# Altium schematic sheet Beagle Bone Black C Cape USB.SchDoc
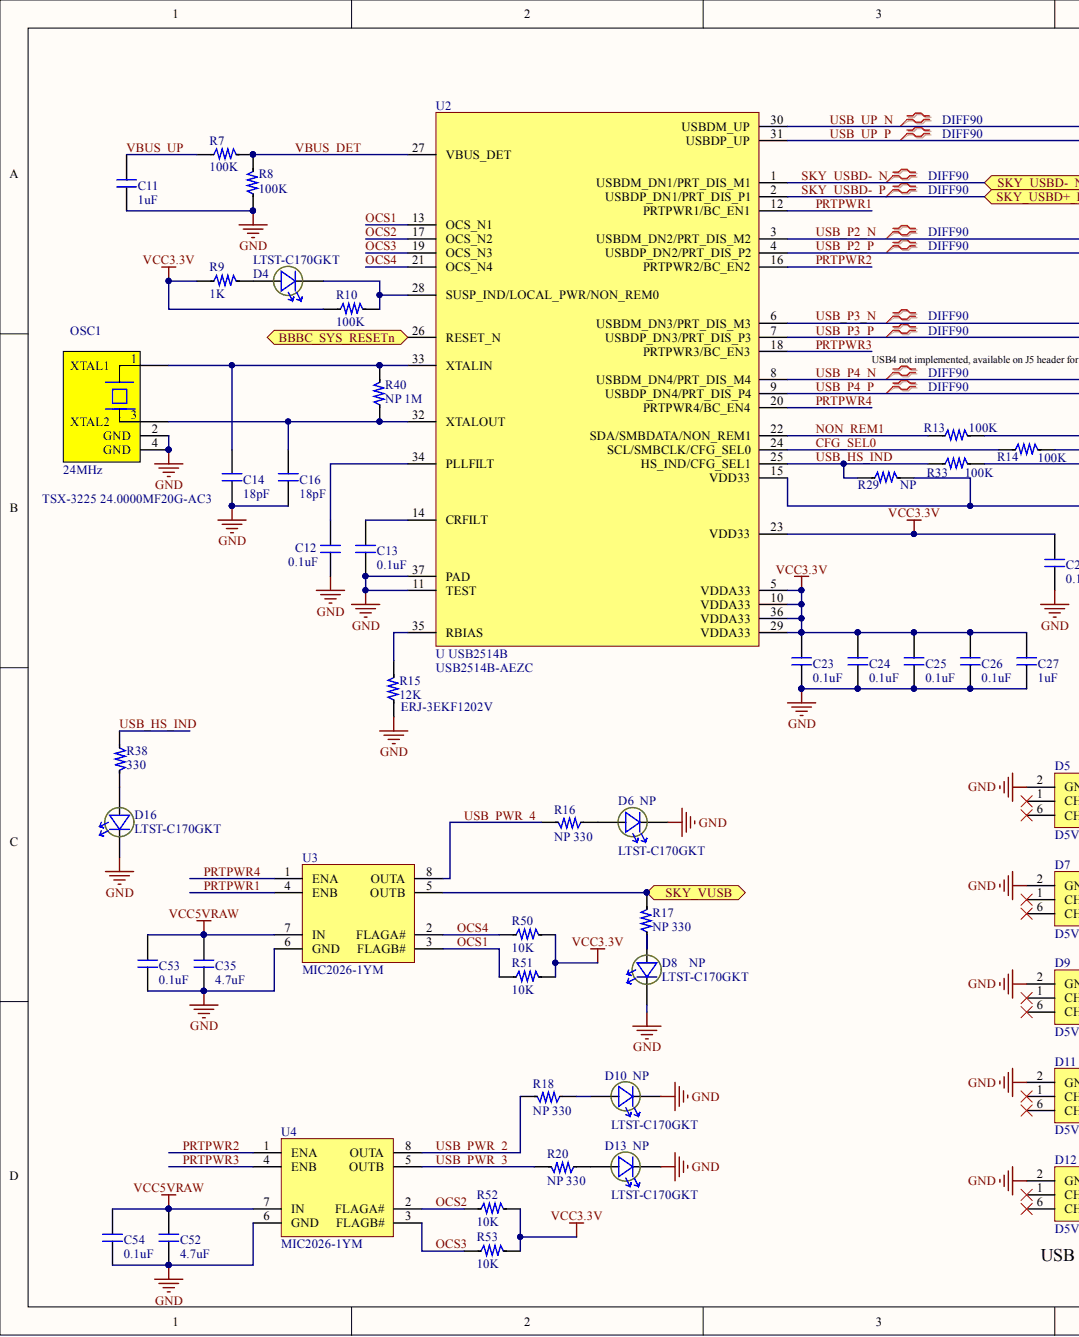
[diagram p0: Beagle Bone Black C Cape USB.SchDoc, left]
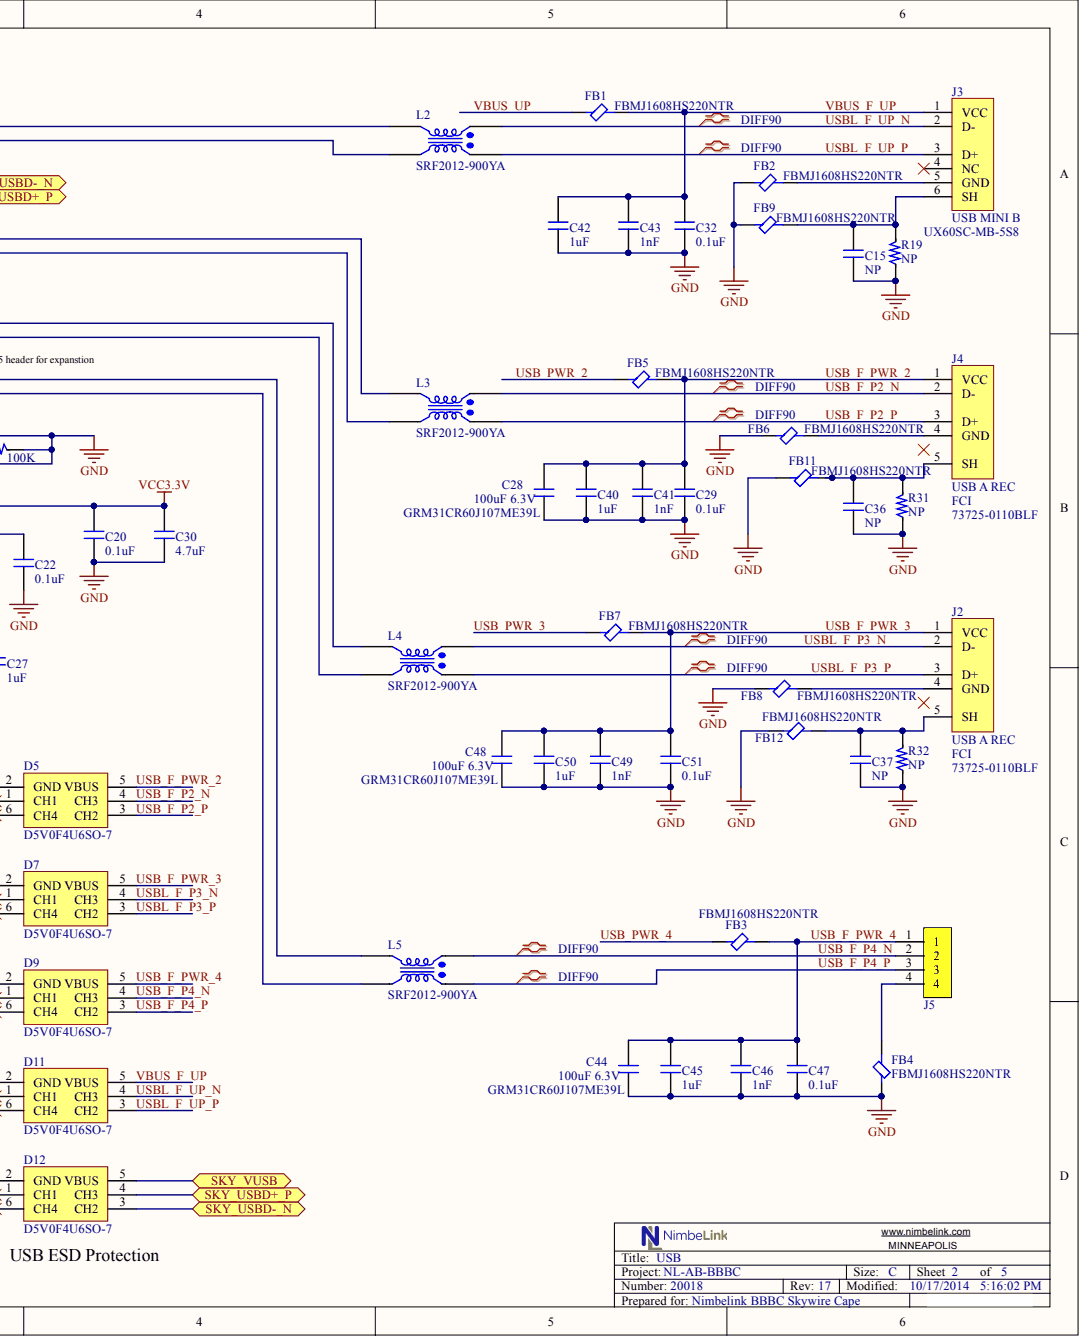
[diagram p1: Beagle Bone Black C Cape USB.SchDoc, right]

## Parts
C11  1uF  [0603]
C12  0.1uF  [0603]
C13  0.1uF  [0603]
C14  18pF  [0603]
C15  NP  [0603]
C16  18pF  [0603]
C20  0.1uF  [0603]
C22  0.1uF  [0603]
C23  0.1uF  [0603]
C24  0.1uF  [0603]
C25  0.1uF  [0603]
C26  0.1uF  [0603]
C27  1uF  [0603]
C28  100uF 6.3V  Manufacturer Part Number 1: GRM31CR60J107ME39L  Voltage - Rated: 6.3V  [1206]
C29  0.1uF  [0603]
C30  4.7uF  [0603]
C32  0.1uF  [0603]
C35  4.7uF  [0603]
C36  NP  [0603]
C37  NP  [0603]
C40  1uF  [0603]
C41  1nF  [0603]
C42  1uF  [0603]
C43  1nF  [0603]
C44  100uF 6.3V  Manufacturer Part Number 1: GRM31CR60J107ME39L  Voltage - Rated: 6.3V  [1206]
C45  1uF  [0603]
C46  1nF  [0603]
C47  0.1uF  [0603]
C48  100uF 6.3V  Manufacturer Part Number 1: GRM31CR60J107ME39L  Voltage - Rated: 6.3V  [1206]
C49  1nF  [0603]
C50  1uF  [0603]
C51  0.1uF  [0603]
C52  4.7uF  [0603]
C53  0.1uF  [0603]
C54  0.1uF  [0603]
D4  Manufacturer Part Number 1: LTST-C170GKT  [D_LED_GREEN]  (hidden comment: D_LED_GREEN)
D5  D5V0F4U6SO-7  [D5V0F4U6SO-7]
D6  NP  Manufacturer Part Number 1: LTST-C170GKT  [D_LED_GREEN]
D7  D5V0F4U6SO-7  [D5V0F4U6SO-7]
D8  NP  Manufacturer Part Number 1: LTST-C170GKT  [D_LED_GREEN]
D9  D5V0F4U6SO-7  [D5V0F4U6SO-7]
D10  NP  Manufacturer Part Number 1: LTST-C170GKT  [D_LED_GREEN]
D11  D5V0F4U6SO-7  [D5V0F4U6SO-7]
D12  D5V0F4U6SO-7  [D5V0F4U6SO-7]
D13  NP  Manufacturer Part Number 1: LTST-C170GKT  [D_LED_GREEN]
D16  Manufacturer Part Number 1: LTST-C170GKT  [D_LED_GREEN]  (hidden comment: D_LED_GREEN)
FB1  Manufacturer Part Number 1: FBMJ1608HS220NTR  [0603]  (hidden comment: FB 7.5A 22Ohm 0.04DCR 0603)
FB2  Manufacturer Part Number 1: FBMJ1608HS220NTR  [0603]  (hidden comment: FB 7.5A 22Ohm 0.04DCR 0603)
FB3  Manufacturer Part Number 1: FBMJ1608HS220NTR  [0603]  (hidden comment: FB 7.5A 22Ohm 0.04DCR 0603)
FB4  Manufacturer Part Number 1: FBMJ1608HS220NTR  [0603]  (hidden comment: FB 7.5A 22Ohm 0.04DCR 0603)
FB5  Manufacturer Part Number 1: FBMJ1608HS220NTR  [0603]  (hidden comment: FB 7.5A 22Ohm 0.04DCR 0603)
FB6  Manufacturer Part Number 1: FBMJ1608HS220NTR  [0603]  (hidden comment: FB 7.5A 22Ohm 0.04DCR 0603)
FB7  Manufacturer Part Number 1: FBMJ1608HS220NTR  [0603]  (hidden comment: FB 7.5A 22Ohm 0.04DCR 0603)
FB8  Manufacturer Part Number 1: FBMJ1608HS220NTR  [0603]  (hidden comment: FB 7.5A 22Ohm 0.04DCR 0603)
FB9  Manufacturer Part Number 1: FBMJ1608HS220NTR  [0603]  (hidden comment: FB 7.5A 22Ohm 0.04DCR 0603)
FB11  Manufacturer Part Number 1: FBMJ1608HS220NTR  [0603]  (hidden comment: FB 7.5A 22Ohm 0.04DCR 0603)
FB12  Manufacturer Part Number 1: FBMJ1608HS220NTR  [0603]  (hidden comment: FB 7.5A 22Ohm 0.04DCR 0603)
J2  USB A REC  Manufacturer 1: FCI  Manufacturer Part Number 1: 73725-0110BLF  [USBA FCI VERT]
J3  USB MINI B  [USB MINI B]
J4  USB A REC  Manufacturer 1: FCI  Manufacturer Part Number 1: 73725-0110BLF  [USBA FCI VERT]
J5  NP  [HEADER 4PIN 2MMPITCH]  (value not drawn)
L2  SRF2012-900YA  [SRF2012-900YA]
L3  SRF2012-900YA  [SRF2012-900YA]
L4  SRF2012-900YA  [SRF2012-900YA]
L5  SRF2012-900YA  [SRF2012-900YA]
OSC1  24MHz  [OSC 008-0288-0_F]
R7  100K  [0603]
R8  100K  [0603]
R9  1K  [0603]
R10  100K  [0603]
R13  100K  [0603]
R14  100K  [0603]
R15  12K  Manufacturer Part Number 1: ERJ-3EKF1202V  [0603]
R16  NP 330  [0603]
R17  NP 330  [0603]
R18  NP 330  [0603]
R19  NP  [0603]
R20  NP 330  [0603]
R29  NP  [0603]
R31  NP  [0603]
R32  NP  [0603]
R33  100K  [0603]
R38  330  [0603]
R40  NP 1M  [0603]
R50  10K  [0603]
R51  10K  [0603]
R52  10K  [0603]
R53  10K  [0603]
U2  U USB2514B  Manufacturer Part Number 1: USB2514B-AEZC  [USB2514]
U3  Manufacturer Part Number 1: MIC2026-1YM  [MIC2026]  (hidden comment: U MIC2026)
U4  Manufacturer Part Number 1: MIC2026-1YM  [MIC2026]  (hidden comment: U MIC2026)

## Ports
BBBC_SYS_RESETN, SKY_USBD+_P, SKY_USBD-_N, SKY_VUSB

## Nets
GND: C11.2 C12.2 C13.2 C14.1 C15.2 C16.1 C20.2 C22.2 C23.2 C24.2 C25.2 C26.2 C27.2 C28.2 C29.2 C30.2 C32.2 C35.2 C36.2 C37.2 C40.2 C41.2 C42.2 C43.2 C44.2 C45.2 C46.2 C47.2 C48.2 C49.2 C50.2 C51.2 C52.2 C53.2 C54.2 D5.2(GND) D6.2 D7.2(GND) D8.2 D9.2(GND) D10.2 D11.2(GND) D12.2(GND) D13.2 D16.2 FB2.1 FB4.1 FB6.1 FB8.1 FB9.1 FB11.1 FB12.1 OSC1.2(GND) OSC1.4(GND) R8.1 R13.2 R14.2 R15.1 R19.1 R31.1 R32.1 R33.2 U2.11(TEST) U2.37(PAD) U3.6(GND) U4.6(GND)
VCC3.3V: C20.1 C22.1 C23.1 C24.1 C25.1 C26.1 C27.1 C30.1 R9.1 R10.1 R29.2 R50.2 R51.2 R52.2 R53.2 U2.5(VDDA33) U2.10(VDDA33) U2.15(VDD33) U2.23(VDD33) U2.29(VDDA33) U2.36(VDDA33)
VCC5VRAW: C35.1 C52.1 C53.1 C54.1 U3.7(IN) U4.7(IN)
CFG_SEL0: R14.1 U2.24(SCL/SMBCLK/CFG_SEL0)
NON_REM1: R13.1 U2.22(SDA/SMBDATA/NON_REM1)
OCS1: R51.1 U2.13(OCS_N1) U3.3(FLAGB#)
OCS2: R52.1 U2.17(OCS_N2) U4.2(FLAGA#)
OCS3: R53.1 U2.19(OCS_N3) U4.3(FLAGB#)
OCS4: R50.1 U2.21(OCS_N4) U3.2(FLAGA#)
PRTPWR1: U2.12(PRTPWR1/BC_EN1) U3.4(ENB)
PRTPWR2: U2.16(PRTPWR2/BC_EN2) U4.1(ENA)
PRTPWR3: U2.18(PRTPWR3/BC_EN3) U4.4(ENB)
PRTPWR4: U2.20(PRTPWR4/BC_EN4) U3.1(ENA)
SKY_USBD-_N: D12.3(CH2) U2.1(USBDM_DN1/PRT_DIS_M1) ; port SKY_USBD-_N
SKY_USBD-_P: D12.4(CH3) U2.2(USBDP_DN1/PRT_DIS_P1) ; port SKY_USBD+_P
SKY_VUSB: D12.5(VBUS) R17.2 U3.5(OUTB) ; port SKY_VUSB
USB_F_P2_N: D5.4(CH3) J4.2(D-) L3.1
USB_F_P2_P: D5.3(CH2) J4.3(D+) L3.2
USB_F_P4_N: D9.4(CH3) J5.2 L5.1
USB_F_P4_P: D9.3(CH2) J5.3 L5.2
USB_F_PWR_2: C28.1 C29.1 C40.1 C41.1 D5.5(VBUS) FB5.2 J4.1(VCC)
USB_F_PWR_3: C48.1 C49.1 C50.1 C51.1 D7.5(VBUS) FB7.2 J2.1(VCC)
USB_F_PWR_4: C44.1 C45.1 C46.1 C47.1 D9.5(VBUS) FB3.2 J5.1
USB_HS_IND: R29.1 R33.1 R38.1 U2.25(HS_IND/CFG_SEL1)
USB_P2_N: L3.4 U2.3(USBDM_DN2/PRT_DIS_M2)
USB_P2_P: L3.3 U2.4(USBDP_DN2/PRT_DIS_P2)
USB_P3_N: L4.4 U2.6(USBDM_DN3/PRT_DIS_M3)
USB_P3_P: L4.3 U2.7(USBDP_DN3/PRT_DIS_P3)
USB_P4_N: L5.4 U2.8(USBDM_DN4/PRT_DIS_M4)
USB_P4_P: L5.3 U2.9(USBDP_DN4/PRT_DIS_P4)
USB_PWR_2: FB5.1 R18.2 U4.8(OUTA)
USB_PWR_3: FB7.1 R20.2 U4.5(OUTB)
USB_PWR_4: FB3.1 R16.2 U3.8(OUTA)
USB_UP_N: L2.4 U2.30(USBDM_UP)
USB_UP_P: L2.3 U2.31(USBDP_UP)
USBL_F_P3_N: D7.4(CH3) J2.2(D-) L4.1
USBL_F_P3_P: D7.3(CH2) J2.3(D+) L4.2
USBL_F_UP_N: D11.4(CH3) J3.2(D-) L2.1
USBL_F_UP_P: D11.3(CH2) J3.3(D+) L2.2
VBUS_DET: R7.2 R8.2 U2.27(VBUS_DET)
VBUS_F_UP: C32.1 C42.1 C43.1 D11.5(VBUS) FB1.2 J3.1(VCC)
VBUS_UP: C11.1 FB1.1 R7.1
NetC12_1: C12.1 U2.34(PLLFILT)
NetC13_1: C13.1 U2.14(CRFILT)
NetC14_2: C14.2 OSC1.1(XTAL1) R40.2 U2.33(XTALIN)
NetC15_1: C15.1 FB9.2 J3.6(SH) R19.2
NetC16_2: C16.2 OSC1.3(XTAL2) R40.1 U2.32(XTALOUT)
NetC36_1: C36.1 FB11.2 J4.5(SH) R31.2
NetC37_1: C37.1 FB12.2 J2.5(SH) R32.2
NetD4_1: D4.1 R9.2
NetD4_2: D4.2 R10.2 U2.28(SUSP_IND/LOCAL_PWR/NON_REM0)
NetD6_1: D6.1 R16.1
NetD8_1: D8.1 R17.1
NetD10_1: D10.1 R18.1
NetD13_1: D13.1 R20.1
NetD16_1: D16.1 R38.2
NetFB2_2: FB2.2 J3.5(GND)
NetFB4_2: FB4.2 J5.4
NetFB6_2: FB6.2 J4.4(GND)
NetFB8_2: FB8.2 J2.4(GND)
NetR15_2: R15.2 U2.35(RBIAS)
NetU2_26: U2.26(RESET_N) ; port BBBC_SYS_RESETN

Not connected: D5 1(CH1) 6(CH4); D7 1(CH1) 6(CH4); D9 1(CH1) 6(CH4); D11 1(CH1) 6(CH4); D12 1(CH1) 6(CH4); J3 4(NC)

## Library data of the parts
C11 C27 C40 C42 C45 C50 = Cap 1uF 10V 10% X7R 0603 CER: Manufacturer Part Number 1: C1608X7R1A105K080AC; Brand: TDK; Case Length: 1.6 mm; Case Width: 0.8 mm; Type: Multilayer Ceramic Chip Capacitor; Capacitance: 1 uF; Case Code - in: 0603; Case Code - mm: 1608; Category: Multilayer Ceramic Capacitors MLCC - SMD/SMT; Component Kind: Standard; ComponentLink1Description: http://www.mouser.com/ds/2/400/C1608X7R1A105K080AC-264833.pdf; ComponentLink1URL: http://www.mouser.com/ds/2/400/C1608X7R1A105K080AC-264833.pdf; Description: Cap 1uF 10V 10% X7R 0603 CER; Manufacturer 1: TDK; Minimum Order Quantity: 1; Operating Temperature Range: - 55 C to + 125 C; Package / Case: 0603 (1608 metric); Packaging: Reel; Pricing: 1=0.149, 10=0.059, 100=0.035, 1000=0.028, 4000=0.020, 24000=0.019, 48000=0.018, 100000=0.014 (AUD); Product: General Type MLCCs; Product Category: High Capacitance SMD Ceramic Chip Capacitors; Reeling: True; RoHS: RoHS Compliant; RoHS - Mouser: Y; Standard Pack Qty: 4000; Stock: 152779; Supplier 1: Mouser; Supplier Part Number 1: 810-C1608X7R1A105K; Temperature Coefficient / Code: X7R; Termination Style: SMD/SMT; Tolerance: 10 %; Voltage Rating: 10 V; Library Name: Nimbelink Altium Lib.SchLib
C12 C13 C20 C22-C26 C29 C32 C47 C51 C53 C54 = Cap 0.1uF 16V 10% X7R 0603 CER: Manufacturer 1: Samsung Electro-Mechanics America, Inc; Manufacturer Part Number 1: CL10B104KO8NNNC; Capacitance: 0.1µF; Applications: General Purpose; Category: Capacitors; Component Kind: Standard; ComponentLink1Description: http://www.samsungsem.com/servlet/FileDownload?type=spec&file=CL10B104KO8NNNC.pdf; ComponentLink1URL: http://www.samsungsem.com/servlet/FileDownload?type=spec&file=CL10B104KO8NNNC.pdf; Description: Cap 0.1uF 16V 10% X7R 0603 CER; Features: -; Height - Seated (Max): -; Lead Spacing: -; Lead Style: -; Mounting Type: Surface Mount, MLCC; Operating Temperature: -55°C ~ 125°C; Package / Case: 0603 (1608 Metric); Packaging: Cut Tape (CT); Pricing: 1=0.1, 10=0.019, 25=0.0132, 50=0.0102, 100=0.0086, 250=0.00704, 500=0.006, 1000=0.00468 (USD); Ratings: -; RoHS: RoHS Compliant; Size / Dimension: 0.063" L x 0.031" W (1.60mm x 0.80mm); Stock: 1252951; Supplier 1: Digi-Key; Supplier Part Number 1: 1276-1005-1-ND; Temperature Coefficient: X7R; Thickness (Max): 0.035" (0.90mm); Tolerance: ±10%; Voltage - Rated: 16V; Library Name: Nimbelink Altium Lib.SchLib
C14 C16 = Cap 18pF 100V 5% NP0 0603 CER: Manufacturer 1: Samsung Electro-Mechanics America, Inc; Manufacturer Part Number 1: CL10C180JC8NNNC; Temperature Coefficient: C0G, NP0; Applications: General Purpose; Capacitance: 18pF; Category: Capacitors; Component Kind: Standard; ComponentLink1Description: http://www.samsungsem.com/servlet/FileDownload?type=spec&file=CL10C180JC8NNNC.pdf; ComponentLink1URL: http://www.samsungsem.com/servlet/FileDownload?type=spec&file=CL10C180JC8NNNC.pdf; Description: Cap 18pF 100V 5% NP0 0603 CER; Features: -; Height - Seated (Max): -; Lead Spacing: -; Lead Style: -; Mounting Type: Surface Mount, MLCC; Operating Temperature: -55°C ~ 125°C; Package / Case: 0603 (1608 Metric); Packaging: Cut Tape (CT); Pricing: 1=0.1, 10=0.02, 25=0.014, 50=0.0108, 100=0.0091, 250=0.00744, 500=0.00636, 1000=0.00495 (USD); Ratings: -; RoHS: RoHS Compliant; Size / Dimension: 0.063" L x 0.031" W (1.60mm x 0.80mm); Stock: 173724; Supplier 1: Digi-Key; Supplier Part Number 1: 1276-2210-1-ND; Thickness (Max): 0.035" (0.90mm); Tolerance: ±5%; Voltage - Rated: 100V; Library Name: Nimbelink Altium Lib.SchLib
C15 C36 C37 = Cap: Component Kind: Standard; Description: Cap; Library Name: Nimbelink Altium Lib.SchLib
C28 C44 = Cap 100uF 6.3V 20% X5R 1206 CER: Manufacturer 1: Murata Electronics North America; Capacitance: 100µF; Applications: General Purpose; Category: Capacitors; Component Kind: Standard; ComponentLink1Description: http://www.murata.com/products/catalog/pdf/c02e.pdf; ComponentLink1URL: http://www.murata.com/products/catalog/pdf/c02e.pdf; Description: Cap 100uF 6.3V 20% X5R 1206 CER; Features: -; Height - Seated (Max): -; Lead Spacing: -; Lead Style: -; Mounting Type: Surface Mount, MLCC; Operating Temperature: -55°C ~ 85°C; Package / Case: 1206 (3216 Metric); Packaging: Cut Tape (CT); Pricing: 1=0.81, 10=0.689, 50=0.507, 100=0.403, 250=0.338, 500=0.3055, 1000=0.2795 (USD); Ratings: -; RoHS: RoHS Compliant; Size / Dimension: 0.126" L x 0.063" W (3.20mm x 1.60mm); Stock: 5629; Supplier 1: Digi-Key; Supplier Part Number 1: 490-4539-1-ND; Temperature Coefficient: X5R; Thickness (Max): 0.071" (1.80mm); Tolerance: ±20%; Library Name: Nimbelink Altium Lib.SchLib
C30 C35 C52 = Cap 4.7UF 10V Y5V 0603: Manufacturer 1: Murata Electronics North America; Manufacturer Part Number 1: GRM188F51A475ZE20D; Capacitance: 4.7µF; Temperature Coefficient: Y5V (F); Tolerance: -20%, +80%; Applications: General Purpose; Category: Capacitors; Component Kind: Standard; ComponentLink1Description: http://search.murata.co.jp/Ceramy/image/img/A01X/G101/ENG/GRM188F51A475ZE20-01.pdf; ComponentLink1URL: http://search.murata.co.jp/Ceramy/image/img/A01X/G101/ENG/GRM188F51A475ZE20-01.pdf; Description: Cap 4.7UF 10V Y5V 0603; Features: -; Height - Seated (Max): -; Lead Spacing: -; Lead Style: -; Mounting Type: Surface Mount, MLCC; Operating Temperature: -30°C ~ 85°C; Package / Case: 0603 (1608 Metric); Packaging: Cut Tape (CT); Pricing: 1=0.16, 10=0.11, 50=0.0634, 100=0.0523, 250=0.04408, 500=0.0369, 1000=0.0297 (USD); Ratings: -; RoHS: RoHS Compliant; Size / Dimension: 0.063" L x 0.031" W (1.60mm x 0.80mm); Stock: 105934; Supplier 1: Digi-Key; Supplier Part Number 1: 490-3302-1-ND; Thickness (Max): 0.035" (0.90mm); Voltage - Rated: 10V; Library Name: Nimbelink Altium Lib.SchLib
C41 C43 C46 C49 = Cap 1nF 50V 10% X7R 0603 CER: Manufacturer 1: Murata Electronics North America; Manufacturer Part Number 1: GRM188R71H102KA01D; Capacitance: 1000pF; Applications: General Purpose; Category: Capacitors; Component Kind: Standard; ComponentLink1Description: http://www.murata.com/products/catalog/pdf/c02e.pdf; ComponentLink1URL: http://www.murata.com/products/catalog/pdf/c02e.pdf; Description: Cap 1nF 50V 10% X7R 0603 CER; Features: -; Height - Seated (Max): -; Lead Spacing: -; Lead Style: -; Mounting Type: Surface Mount, MLCC; Operating Temperature: -55°C ~ 125°C; Package / Case: 0603 (1608 Metric); Packaging: Cut Tape (CT); Pricing: 1=0.1, 10=0.02, 50=0.0108, 100=0.0091, 250=0.00744, 500=0.00636, 1000=0.00495 (USD); Ratings: -; RoHS: RoHS Compliant; Size / Dimension: 0.063" L x 0.031" W (1.60mm x 0.80mm); Stock: 701490; Supplier 1: Digi-Key; Supplier Part Number 1: 490-1494-1-ND; Temperature Coefficient: X7R; Thickness (Max): 0.035" (0.90mm); Tolerance: ±10%; Voltage - Rated: 50V; Library Name: Nimbelink Altium Lib.SchLib
C48 = Cap 100uF 6.3V 20% X5R 1206 CER: Capacitance: 100µF; Applications: General Purpose; Category: Capacitors; Component Kind: Standard; ComponentLink1Description: http://www.murata.com/products/catalog/pdf/c02e.pdf; ComponentLink1URL: http://www.murata.com/products/catalog/pdf/c02e.pdf; Description: Cap 100uF 6.3V 20% X5R 1206 CER; Features: -; Height - Seated (Max): -; Lead Spacing: -; Lead Style: -; Mounting Type: Surface Mount, MLCC; Operating Temperature: -55°C ~ 85°C; Package / Case: 1206 (3216 Metric); Packaging: Cut Tape (CT); Pricing: 1=0.81, 10=0.689, 50=0.507, 100=0.403, 250=0.338, 500=0.3055, 1000=0.2795 (USD); Ratings: -; RoHS: RoHS Compliant; Size / Dimension: 0.126" L x 0.063" W (3.20mm x 1.60mm); Stock: 5629; Supplier 1: Digi-Key; Supplier Part Number 1: 490-4539-1-ND; Temperature Coefficient: X5R; Thickness (Max): 0.071" (1.80mm); Tolerance: ±20%; Library Name: Nimbelink Altium Lib.SchLib
D4 D6 D8 D10 D13 D16 = D_LED_GREEN: LED GREEN  SMD; Brand: Lite-On; Forward Current: 20 mA; Forward Voltage: 2.1 V; LED Size: 2 mm x 1.25 mm; Lens Color/Style: Water Clear; Luminous Intensity: 6 mcd; Viewing Angle: 130 deg; Wavelength/Color Temperature: 569 nm; Category: Standard LEDs - SMD; Component Kind: Standard; ComponentLink1Description: http://www.mouser.com/catalog/specsheets/Lite-On-LTST-C170GKT.pdf; ComponentLink1URL: http://www.mouser.com/catalog/specsheets/Lite-On-LTST-C170GKT.pdf; Illumination Color: Green; Manufacturer 1: Lite-On; Maximum Operating Temperature: + 85 C; Minimum Operating Temperature: - 55 C; Minimum Order Quantity: 1; Package / Case: 0805; Packaging: Reel; Pricing: 1=0.513, 10=0.371, 100=0.217, 250=0.154, 500=0.115, 1000=0.089, 3000=0.077, 6000=0.063, 12000=0.058 (AUD); Product Category: SMT LED; Reeling: True; RoHS: RoHS Compliant; RoHS - Mouser: Y; Standard Pack Qty: 3000; Stock: 13646; Supplier 1: Mouser; Supplier Part Number 1: 859-LTST-C170GKT; Library Name: Nimbelink Altium Lib.SchLib
  D16: : DNP
D5 D7 D9 D11 D12 = D TVS USB HIGH SPEED D5V0F4U6SO-7: USB ESD Protection D5V0F4U6SO-7; Manufacturer 1: Diodes Incorporated; Bidirectional Channels: 4; Capacitance @ Frequency: 0.5pF @ 1MHz; Current - Peak Pulse (10/1000µs): 1A (8/20µs); Power Line Protection: Yes; Type: Steering (Rail to Rail); Voltage - Breakdown (Min): 6V; Voltage - Clamping (Max) @ Ipp: 12V; Voltage - Reverse Standoff (Typ): 5.5V (Max); Applications: HDMI; Category: Circuit Protection; Component Kind: Standard; ComponentLink1Description: http://www.diodes.com/datasheets/D5V0F4U6SO.pdf; ComponentLink1URL: http://www.diodes.com/datasheets/D5V0F4U6SO.pdf; Manufacturer Part Number 1: D5V0F4U6SO-7; Mounting Type: Surface Mount; Operating Temperature: -65°C ~ 150°C (TJ); Package / Case: SOT-23-6; Packaging: Cut Tape (CT); Power - Peak Pulse: -; Pricing: 1=0.47, 10=0.328, 25=0.2692, 100=0.2152, 250=0.15676, 500=0.1273, 1000=0.09785 (USD); RoHS: RoHS Compliant; Stock: 102; Supplier 1: Digi-Key; Supplier Device Package: SOT-26; Supplier Part Number 1: D5V0F4U6SO-7DICT-ND; Unidirectional Channels: -; Library Name: Nimbelink Altium Lib.SchLib
FB1-FB9 FB11 FB12 = FB 7.5A 22Ohm 0.04DCR 0603: Manufacturer 1: Taiyo Yuden; DC Resistance (DCR): 4 mOhm Max; Filter Type: Differential Mode - Single; Impedance @ Frequency: 22 Ohm @ 100MHz; Category: Filters; Component Kind: Standard; ComponentLink1Description: http://www.yuden.co.jp/productdata/catalog/en/chipbeads01_e.pdf; ComponentLink1URL: http://www.yuden.co.jp/productdata/catalog/en/chipbeads01_e.pdf; Current Rating: 7.5A; Height (Max): 0.039" (1.00mm); Mounting Type: Surface Mount; Package / Case: 0603 (1608 Metric); Packaging: Cut Tape (CT); Pricing: 1=0.13, 10=0.105, 25=0.094, 50=0.069, 100=0.0596, 250=0.05016, 500=0.03972, 1000=0.03344 (USD); RoHS: RoHS Compliant; Size / Dimension: 0.063" L x 0.031" W (1.60mm x 0.80mm); Stock: 7682; Supplier 1: Digi-Key; Supplier Part Number 1: 587-3253-1-ND; Library Name: Nimbelink Altium Lib.SchLib
J2 J4 = J USB A REC: Connector Type: USB - A; Gender: Receptacle; Number of Contacts: 4; Specifications: USB 2.0; Category: Connectors, Interconnects; Component Kind: Standard; ComponentLink1Description: http://portal.fciconnect.com/Comergent//fci/drawing/73725.pdf; ComponentLink1URL: http://portal.fciconnect.com/Comergent//fci/drawing/73725.pdf; Features: Board Lock; Ingress Protection: -; Mounting Type: Through Hole, Right Angle, Vertical; Packaging: Tube; Pricing: 1=0.85, 10=0.747, 25=0.7036, 50=0.6742, 100=0.6448, 250=0.5862, 500=0.52758, 1000=0.46896, 2500=0.425 (USD); RoHS: RoHS Compliant; Stock: 33465; Supplier 1: Digi-Key; Supplier Part Number 1: 609-1041-ND; Library Name: Nimbelink Altium Lib.SchLib
J3 = J USB MINI B: Manufacturer 1: Hirose Electric Co Ltd; Manufacturer Part Number 1: UX60SC-MB-5S8; Connector Type: USB - mini B; Gender: Receptacle; Number of Contacts: 5; Specifications: USB 2.0; Category: Connectors, Interconnects; Component Kind: Standard; ComponentLink1Description: http://www.hirose.co.jp/cataloge_hp/e24000153.pdf; ComponentLink1URL: http://www.hirose.co.jp/cataloge_hp/e24000153.pdf; Features: Board Guide, Solder Retention; Ingress Protection: -; Mounting Type: Surface Mount, Right Angle, Horizontal; Packaging: Cut Tape (CT); Pricing: 1=1.15, 10=1.01, 25=0.9504, 50=0.9108, 100=0.8712, 250=0.792, 500=0.7128 (USD); RoHS: RoHS Compliant; Stock: 9122; Supplier 1: Digi-Key; Supplier Part Number 1: H11589CT-ND; Library Name: Nimbelink Altium Lib.SchLib
J5 = Header 4PIN 2mmpitch: Component Kind: Standard; Library Name: Nimbelink Altium Lib.SchLib
L2-L5 = L USB Common Choke 90OHM SRF2012-900YA: Manufacturer 1: Bourns Inc.; Current: 400mA; DC Resistance (DCR): 300 mOhm Max; Filter Type: Power or Data Line; Impedance: 90 Ohm; Number of Lines: 2; Category: Filters; Component Kind: Standard; ComponentLink1Description: http://www.bourns.com/data/global/pdfs/SRF2012A.pdf; ComponentLink1URL: http://www.bourns.com/data/global/pdfs/SRF2012A.pdf; Height (Max): 0.055" (1.40mm); Inductance: -; Manufacturer Part Number 1: SRF2012-900YA; Mounting Type: Surface Mount; Operating Temperature: -40°C ~ 125°C; Package / Case: Horizontal, 4 PC Pad; Packaging: Tape & Reel (TR); Pricing: 2000=0.1008, 4000=0.0952, 6000=0.0931 (USD); RoHS: RoHS Compliant; Size / Dimension: 0.079" L x 0.047" W (2.00mm x 1.20mm); Stock: 70000; Supplier 1: Digi-Key; Supplier Part Number 1: SRF2012-900YATR-ND; Library Name: Nimbelink Altium Lib.SchLib
OSC1 = CRYSTAL 24MHz 18pF: Manufacturer 1: CTS-Frequency Controls; Manufacturer Part Number 1: 403C35D24M00000; ESR (Equivalent Series Resistance): 60 Ohm; Frequency Stability: ±50ppm; Frequency Tolerance: ±30ppm; Operating Mode: Fundamental; : TSX-3225 24.0000MF20G-AC3; Category: Crystals and Oscillators; Component Kind: Standard; ComponentLink1Description: http://www.ctscorp.com/components/Datasheets/008-0288-0_F.pdf; ComponentLink1URL: http://www.ctscorp.com/components/Datasheets/008-0288-0_F.pdf; Description: CRYSTAL 24MHz 18pF; Frequency: 24MHz; Height: 0.031" (0.80mm); Load Capacitance: 18pF; Mounting Type: Surface Mount; Operating Temperature: -20°C ~ 70°C; Package / Case: 4-SMD, No Lead (DFN, LCC); Packaging: Cut Tape (CT); Pricing: 1=0.79, 10=0.702, 50=0.6554, 100=0.58, 500=0.5481, 750=0.51911, 1000=0.4582 (USD); Ratings: -; RoHS: RoHS Compliant; Size / Dimension: 0.126" L x 0.098" W (3.20mm x 2.50mm); Stock: 4839; Supplier 1: Digi-Key; Supplier Part Number 1: CTX842CT-ND; Type: MHz Crystal; Library Name: Nimbelink Altium Lib.SchLib
R7 R8 R10 R13 R14 R33 = RES 100K 1/10W 1% 0603: Manufacturer 1: Samsung Electro-Mechanics America, Inc; Manufacturer Part Number 1: RC1608F104CS; Power (Watts): 0.1W, 1/10W; Temperature Coefficient: ±100ppm/°C; Category: Resistors; Component Kind: Standard; ComponentLink1Description: http://www.samsungsem.com/servlet/FileDownload?filename=productcatalog/pdf/Chip_Resistor.pdf; ComponentLink1URL: http://www.samsungsem.com/servlet/FileDownload?filename=productcatalog/pdf/Chip_Resistor.pdf; Composition: Thick Film; Description: RES 100K 1/10W 1% 0603; Features: -; Height: 0.022" (0.55mm); Number of Terminations: 2; Package / Case: 0603 (1608 Metric); Packaging: Cut Tape (CT); Pricing: 1=0.1, 10=0.014, 25=0.0104, 50=0.008, 100=0.0058, 250=0.00444, 500=0.00356, 1000=0.00262, 2500=0.00228 (USD); Resistance (Ohms): 100k; RoHS: RoHS Compliant; Size / Dimension: 0.063" L x 0.031" W (1.60mm x 0.80mm); Stock: 2884; Supplier 1: Digi-Key; Supplier Device Package: 0603 (1608 Metric); Supplier Part Number 1: 1276-3486-1-ND; Tolerance: ±1%; Library Name: Nimbelink Altium Lib.SchLib
R9 = RES 1K 1/10W 1% 0603: Manufacturer 1: Stackpole Electronics Inc; Manufacturer Part Number 1: RNCP0603FTD1K00; Power (Watts): 0.125W, 1/8W; Temperature Coefficient: ±100ppm/°C; Category: Resistors; Component Kind: Standard; ComponentLink1Description: http://www.seielect.com/catalog/SEI-RNCP.pdf; ComponentLink1URL: http://www.seielect.com/catalog/SEI-RNCP.pdf; Composition: Thin Film; Description: RES 1K 1/10W 1% 0603; Features: Anti-Sulfur; Height: 0.020" (0.50mm); Number of Terminations: 2; Package / Case: 0603 (1608 Metric); Packaging: Cut Tape (CT); Pricing: 1=0.05, 10=0.038, 50=0.0208, 100=0.0158, 250=0.01172, 500=0.00864, 1000=0.00576, 2500=0.00486 (USD); Resistance (Ohms): 1k; RoHS: RoHS Compliant; Size / Dimension: 0.059" L x 0.031" W (1.50mm x 0.80mm); Stock: 1735; Supplier 1: Digi-Key; Supplier Device Package: 0603; Supplier Part Number 1: RNCP0603FTD1K00CT-ND; Tolerance: ±1%; Library Name: Nimbelink Altium Lib.SchLib
R15 = RES 12K 1/10W 1% 0603: Manufacturer 1: Panasonic Electronic Components; Power (Watts): 0.1W, 1/10W; Temperature Coefficient: ±100ppm/°C; Category: Resistors; Component Kind: Standard; ComponentLink1Description: http://industrial.panasonic.com/www-cgi/jvcr13pz.cgi?E+PZ+3+AOA0002+ERJ3EKF1202V+7+WW; ComponentLink1URL: http://industrial.panasonic.com/www-cgi/jvcr13pz.cgi?E+PZ+3+AOA0002+ERJ3EKF1202V+7+WW; Composition: Thick Film; Description: RES 12K 1/10W 1% 0603; Features: -; Height: 0.022" (0.55mm); Number of Terminations: 2; Package / Case: 0603 (1608 Metric); Packaging: Cut Tape (CT); Pricing: 1=0.1, 50=0.015, 100=0.0114, 250=0.00844, 500=0.00624, 1000=0.00416, 2500=0.00351 (USD); Resistance (Ohms): 12k; RoHS: RoHS Compliant; Size / Dimension: 0.063" L x 0.031" W (1.60mm x 0.80mm); Stock: 230759; Supplier 1: Digi-Key; Supplier Device Package: 0603; Supplier Part Number 1: P12.0KHCT-ND; Tolerance: ±1%; Library Name: Nimbelink Altium Lib.SchLib
R16-R18 R20 = RES 330 1/10W 1% 0603: Manufacturer 1: Panasonic Electronic Components; Manufacturer Part Number 1: ERJ-3GEYJ331V; Power (Watts): 0.1W, 1/10W; Resistance (Ohms): 330; Temperature Coefficient: ±200ppm/°C; Tolerance: ±5%; Category: Resistors; Component Kind: Standard; ComponentLink1Description: http://industrial.panasonic.com/www-cgi/jvcr13pz.cgi?E+PZ+3+AOA0001+ERJ3GEYJ331V+7+WW; ComponentLink1URL: http://industrial.panasonic.com/www-cgi/jvcr13pz.cgi?E+PZ+3+AOA0001+ERJ3GEYJ331V+7+WW; Composition: Thick Film; Description: RES 330 1/10W 1% 0603; Features: Automotive AEC-Q200 Qualified; Height: 0.022" (0.55mm); Number of Terminations: 2; Package / Case: 0603 (1608 Metric); Packaging: Cut Tape (CT); Pricing: 1=0.1, 50=0.0126, 100=0.0096, 250=0.00716, 500=0.00528, 1000=0.00352, 2500=0.00297 (USD); RoHS: RoHS Compliant; Size / Dimension: 0.063" L x 0.031" W (1.60mm x 0.80mm); Stock: 1678474; Supplier 1: Digi-Key; Supplier Device Package: 0603; Supplier Part Number 1: P330GCT-ND; Library Name: Nimbelink Altium Lib.SchLib
R19 R31 R32 = RES NP: Component Kind: Standard; Description: NP; Library Name: Nimbelink Altium Lib.SchLib
R29 = R: Component Kind: Standard; Description: NP; Library Name: Nimbelink Altium Lib.SchLib
R38 = RES 330 1/10W 1% 0603: Manufacturer 1: Panasonic Electronic Components; Manufacturer Part Number 1: ERJ-3GEYJ331V; Power (Watts): 0.1W, 1/10W; Temperature Coefficient: ±200ppm/°C; Tolerance: ±5%; Category: Resistors; Component Kind: Standard; ComponentLink1Description: http://industrial.panasonic.com/www-cgi/jvcr13pz.cgi?E+PZ+3+AOA0001+ERJ3GEYJ331V+7+WW; ComponentLink1URL: http://industrial.panasonic.com/www-cgi/jvcr13pz.cgi?E+PZ+3+AOA0001+ERJ3GEYJ331V+7+WW; Composition: Thick Film; Description: RES 330 1/10W 1% 0603; Features: Automotive AEC-Q200 Qualified; Height: 0.022" (0.55mm); Number of Terminations: 2; Package / Case: 0603 (1608 Metric); Packaging: Cut Tape (CT); Pricing: 1=0.1, 50=0.0126, 100=0.0096, 250=0.00716, 500=0.00528, 1000=0.00352, 2500=0.00297 (USD); Resistance (Ohms): 330; RoHS: RoHS Compliant; Size / Dimension: 0.063" L x 0.031" W (1.60mm x 0.80mm); Stock: 1678474; Supplier 1: Digi-Key; Supplier Device Package: 0603; Supplier Part Number 1: P330GCT-ND; Library Name: Nimbelink Altium Lib.SchLib
R40 = RES 1M 1/10W 1% 0603: Brand: Panasonic; Case Height: 0.45 mm; Case Length: 1.6 mm; Case Width: 0.8 mm; Power Rating: 100 mW; Resistance: 1 MOhms; Temperature Coefficient: - 400 PPM / C, + 150 PPM / C; Tolerance: 5 %; Voltage Rating: 75 V; Case Code - in: 0603; Case Code - mm: 1608; Category: Thick Film Resistors - SMD; Component Kind: Standard; ComponentLink1Description: http://www.mouser.com/ds/2/315/AOA0000CE1-37422.pdf; ComponentLink1URL: http://www.mouser.com/ds/2/315/AOA0000CE1-37422.pdf; Description: RES 1M 1/10W 1% 0603; Manufacturer 2: Panasonic; Manufacturer Part Number 2: ERJ-3GEYJ105V; Minimum Order Quantity: 1; Operating Temperature Range: - 55 C to + 155 C; Package / Case: 0603 (1608 metric); Packaging: Reel; Pricing: 1=0.135, 10=0.016, 100=0.011, 500=0.008, 1000=0.004, 5000=0.003 (AUD); Product: Thick Film Resistors SMD; Reeling: True; RoHS: RoHS Compliant By Exemption; RoHS - Mouser: E; Series: ERJ; Standard Pack Qty: 5000; Stock: 340338; Supplier 1: Digi-Key; Supplier 2: Mouser; Supplier Part Number 1: P1.0MGTR-ND; Supplier Part Number 2: 667-ERJ-3GEYJ105V; Type: Thick Film Resistors SMD; Library Name: Nimbelink Altium Lib.SchLib
R50-R53 = RES 10K 1/10W 1% 0603: Brand: Panasonic; Case Height: 0.45 mm; Case Length: 1.6 mm; Case Width: 0.8 mm; Power Rating: 100 mW; Resistance: 10 kOhms; Temperature Coefficient: 100 PPM / C; Voltage Rating: 75 V; Case Code - in: 0603; Case Code - mm: 1608; Category: Thick Film Resistors - SMD; Component Kind: Standard; ComponentLink1Description: http://industrial.panasonic.com/www-data/pdf/AOA0000/AOA0000CE2.pdf; ComponentLink1URL: http://industrial.panasonic.com/www-data/pdf/AOA0000/AOA0000CE2.pdf; Description: RES 10K 1/10W 1% 0603; Manufacturer 2: Panasonic; Manufacturer Part Number 2: ERJ-3EKF1002V; Minimum Order Quantity: 1; Operating Temperature Range: - 55 C to + 155 C; Package / Case: 0603 (1608 metric); Packaging: Reel; Pricing: 1=0.135, 10=0.019, 100=0.012, 500=0.009, 1000=0.005, 5000=0.004, 25000=0.003 (AUD); Product: Thick Film Resistors SMD; Reeling: True; RoHS: RoHS Compliant By Exemption; RoHS - Mouser: E; Series: ERJ; Standard Pack Qty: 5000; Stock: 1717350; Supplier 1: Digi-Key; Supplier 2: Mouser; Supplier Part Number 1: P10.0KHCT-ND; Supplier Part Number 2: 667-ERJ-3EKF1002V; Tolerance: 1 %; Type: Precision Thick Film Resistors SMD; Library Name: Nimbelink Altium Lib.SchLib
U2 = U USB2514B: Manufacturer 1: Microchip Technology; Current - Supply: 70mA; Function: Hub Controller; Interface: USB; Voltage - Supply: 3 V ~ 3.6 V; Category: Integrated Circuits (ICs); Component Kind: Standard; ComponentLink1Description: http://ww1.microchip.com/downloads/en/DeviceDoc/251xb.pdf; ComponentLink1URL: http://ww1.microchip.com/downloads/en/DeviceDoc/251xb.pdf; Operating Temperature: 0°C ~ 85°C; Package / Case: 36-VFQFN Exposed Pad; Packaging: Tray; Pricing: 1=2.9, 10=2.42, 25=2.02, 100=1.84 (USD); Protocol: USB; RoHS: RoHS Compliant; Standards: -; Stock: 19358; Supplier 1: Digi-Key; Supplier Device Package: 36-QFN (6x6); Supplier Part Number 1: 638-1122-ND; Library Name: Nimbelink Altium Lib.SchLib
U3 U4 = U MIC2026: Manufacturer 1: Micrel Inc; Current Limit: 900mA; Internal Switch(s): Yes; Number of Outputs: 2; Rds (On): 140 mOhm; Voltage - Input: 2.7 V ~ 5.5 V; Category: Integrated Circuits (ICs); Component Kind: Standard; ComponentLink1Description: http://www.micrel.com/_PDF/mic2026.pdf; ComponentLink1URL: http://www.micrel.com/_PDF/mic2026.pdf; Mounting Type: Surface Mount; Operating Temperature: -40°C ~ 85°C; Package / Case: 8-SOIC (0.154", 3.90mm Width); Packaging: Tube; Pricing: 1=1.34, 10=1.19, 50=1.0746, 100=0.9403, 250=0.82516, 500=0.72922, 1000=0.5757, 2500=0.53732, 5000=0.51045 (USD); RoHS: RoHS Compliant; Stock: 7728; Supplier 1: Digi-Key; Supplier Device Package: 8-SOIC; Supplier Part Number 1: 576-2137-ND; Type: High Side Switch; Library Name: Nimbelink Altium Lib.SchLib
